# Altium schematic sheet digital_indicator.SchDoc(U_digital_indicator)
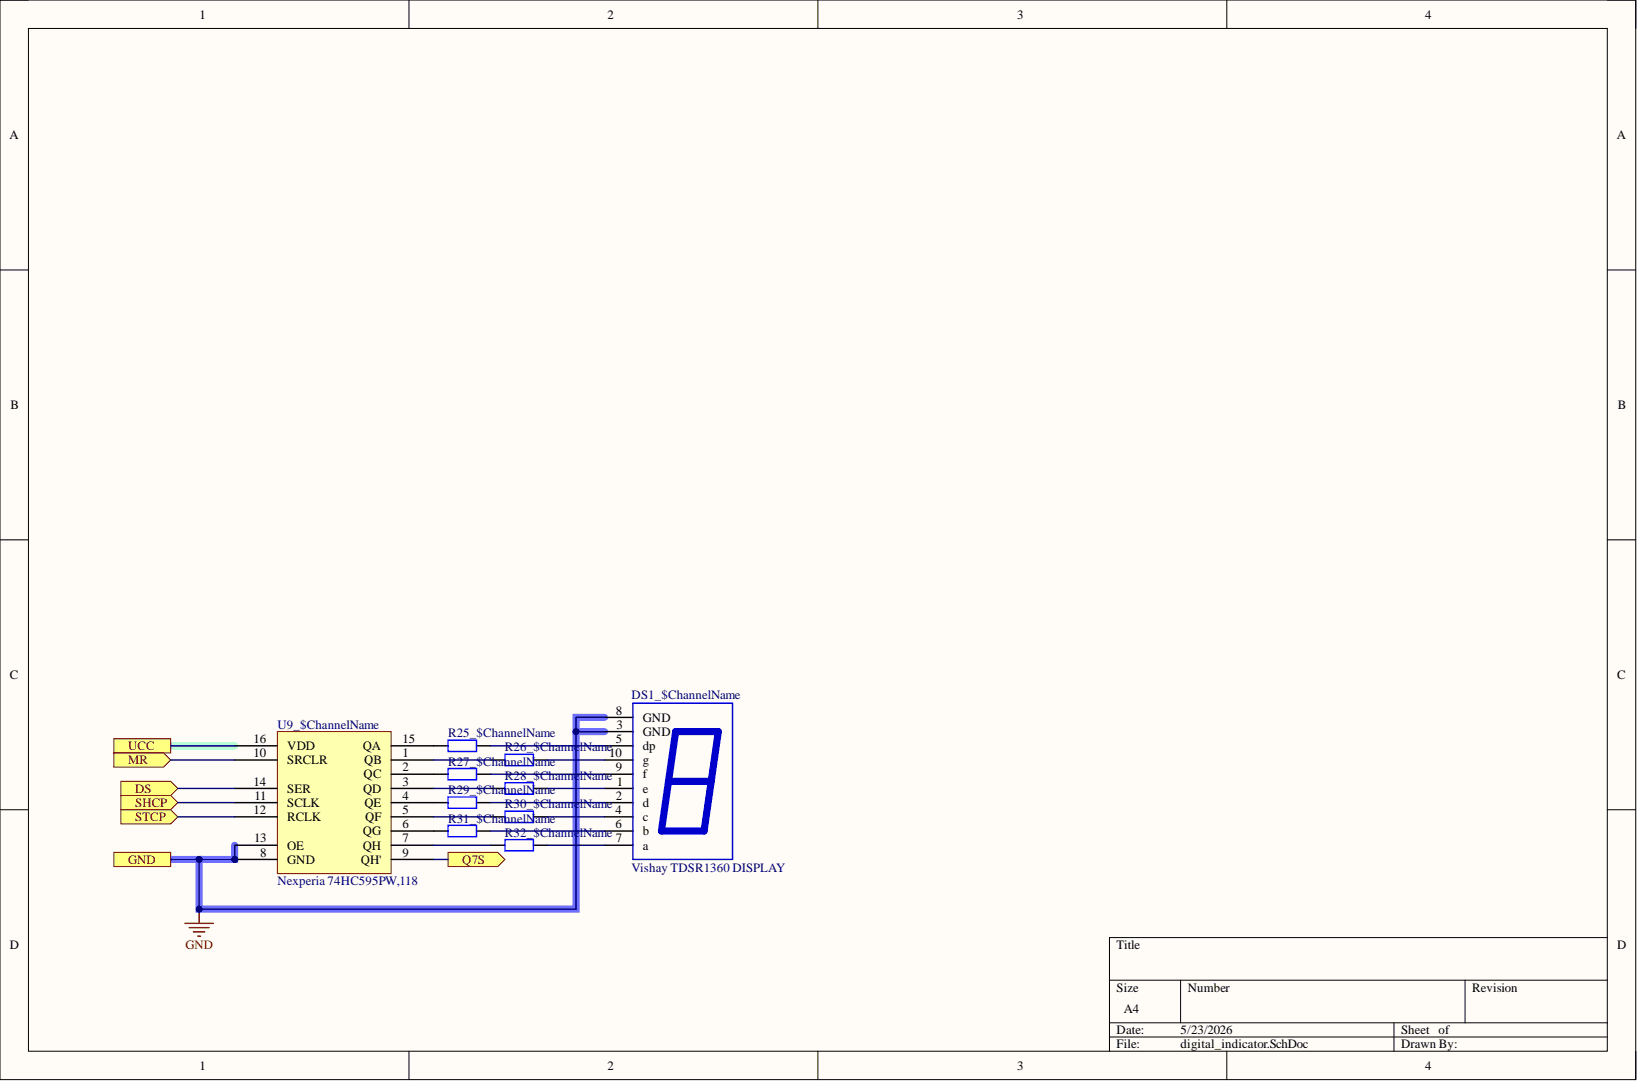
[diagram p0: digital_indicator.SchDoc]

## Parts
DS1_$ChannelName  Vishay TDSR1360 DISPLAY  Manufacturer Part Number: TDSR1360  [PCB-wjfw9shywxa82n8451hf-4]
R25_$ChannelName  Uniroyal 0603WAF3300T5E  [PCB-5zjx0snl4kmm738ena8u-1]  (value not drawn)
R26_$ChannelName  Uniroyal 0603WAF3300T5E  [PCB-5zjx0snl4kmm738ena8u-1]  (value not drawn)
R27_$ChannelName  Uniroyal 0603WAF3300T5E  [PCB-5zjx0snl4kmm738ena8u-1]  (value not drawn)
R28_$ChannelName  Uniroyal 0603WAF3300T5E  [PCB-5zjx0snl4kmm738ena8u-1]  (value not drawn)
R29_$ChannelName  Uniroyal 0603WAF3300T5E  [PCB-5zjx0snl4kmm738ena8u-1]  (value not drawn)
R30_$ChannelName  Uniroyal 0603WAF3300T5E  [PCB-5zjx0snl4kmm738ena8u-1]  (value not drawn)
R31_$ChannelName  Uniroyal 0603WAF3300T5E  [PCB-5zjx0snl4kmm738ena8u-1]  (value not drawn)
R32_$ChannelName  Uniroyal 0603WAF3300T5E  [PCB-5zjx0snl4kmm738ena8u-1]  (value not drawn)
U9_$ChannelName  Nexperia 74HC595PW,118  Manufacturer Part Number: 74HC595PW,118  [PCB-o242chspmi3els652icb-1]

## Ports
DS_$ChannelName, GND_$ChannelName, MR_$ChannelName, Q7S_$ChannelName, SHCP_$ChannelName, STCP_$ChannelName, UCC_$ChannelName

## Nets
GND_$ChannelName: DS1_$ChannelName.3(GND) DS1_$ChannelName.8(GND) U9_$ChannelName.8(GND) U9_$ChannelName.13(OE)
NetDS1_$ChannelName_1: DS1_$ChannelName.1(e) R28_$ChannelName.2
NetDS1_$ChannelName_2: DS1_$ChannelName.2(d) R29_$ChannelName.2
NetDS1_$ChannelName_4: DS1_$ChannelName.4(c) R30_$ChannelName.2
NetDS1_$ChannelName_5: DS1_$ChannelName.5(dp) R25_$ChannelName.2
NetDS1_$ChannelName_6: DS1_$ChannelName.6(b) R31_$ChannelName.2
NetDS1_$ChannelName_7: DS1_$ChannelName.7(a) R32_$ChannelName.2
NetDS1_$ChannelName_9: DS1_$ChannelName.9(f) R27_$ChannelName.2
NetDS1_$ChannelName_10: DS1_$ChannelName.10(g) R26_$ChannelName.2
NetR25_$ChannelName_1: R25_$ChannelName.1 U9_$ChannelName.15(QA)
NetR26_$ChannelName_1: R26_$ChannelName.1 U9_$ChannelName.1(QB)
NetR27_$ChannelName_1: R27_$ChannelName.1 U9_$ChannelName.2(QC)
NetR28_$ChannelName_1: R28_$ChannelName.1 U9_$ChannelName.3(QD)
NetR29_$ChannelName_1: R29_$ChannelName.1 U9_$ChannelName.4(QE)
NetR30_$ChannelName_1: R30_$ChannelName.1 U9_$ChannelName.5(QF)
NetR31_$ChannelName_1: R31_$ChannelName.1 U9_$ChannelName.6(QG)
NetR32_$ChannelName_1: R32_$ChannelName.1 U9_$ChannelName.7(QH)
NetU9_$ChannelName_9: U9_$ChannelName.9(QH')
NetU9_$ChannelName_10: U9_$ChannelName.10(SRCLR)
NetU9_$ChannelName_11: U9_$ChannelName.11(SCLK)
NetU9_$ChannelName_12: U9_$ChannelName.12(RCLK)
NetU9_$ChannelName_14: U9_$ChannelName.14(SER)
NetU9_$ChannelName_16: U9_$ChannelName.16(VDD)

## Library data of the parts
DS1_$ChannelName = CMP-7c47b7143e56a380-9: LED; 280 ucd (Min.) @ 1 mA; 650 nm (Typ.) @ 1 mA; 1.8 V (Typ.) @ 1 mA; 5 V;; Character Size-Height: 13.0 mm; Color: Red; Forward Voltage: 1.80 V; Luminous Intensity: 3.60 mcd; Viewing Angle: 50 °; Case/Package: DIP; Component Kind: Standard; Library Name: My Personal Space; Mounting Style: Through Hole; Number of Pins: 10; Octopart URL: https://octopart.com/tdsr1360-vishay-39413623; OctopartID: 7c47b7143e56a380; Packaging: Tube; RoHS: Compliant
R25_$ChannelName R26_$ChannelName R27_$ChannelName R28_$ChannelName R29_$ChannelName R30_$ChannelName R31_$ChannelName R32_$ChannelName = CMP-d0361cd0d87d0ff1-1: CHIP RESISTOR - SURFACE MOUNT 330OHMS ±1% 1/10W 0603 ROHS; Component Kind: Standard; Library Name: My Personal Space; Manufacturer Part Number: 0603WAF3300T5E; Octopart URL: https://octopart.com/0603waf3300t5e-uniroyal-99364141; OctopartID: d0361cd0d87d0ff1
U9_$ChannelName = CMP-284ce1e6cd9cf78b-7: 74HC(T)595 - 8-bit serial-in, serial or parallel-out shift register with output latches; 3-state; Lead-Free Status: Lead Free; Supply Voltage (DC): 2.00 V (min), 6.00 V (max); Component Kind: Standard; Library Name: My Personal Space; Lifecycle Status: Active; Mounting Style: Surface Mount; Number of Pins: 16; Octopart URL: https://octopart.com/74hc595pw%2C118-nexperia-78736101; OctopartID: 92e35f58dd604d24; Operating Temperature: -40.0 °C (min); Packaging: Tape & Reel (TR), Cut Tape (CT); REACH SVHC Compliance: No SVHC; RoHS: Compliant
